# Altium schematic sheet Rikky-board_v0r0_NM.SchDoc
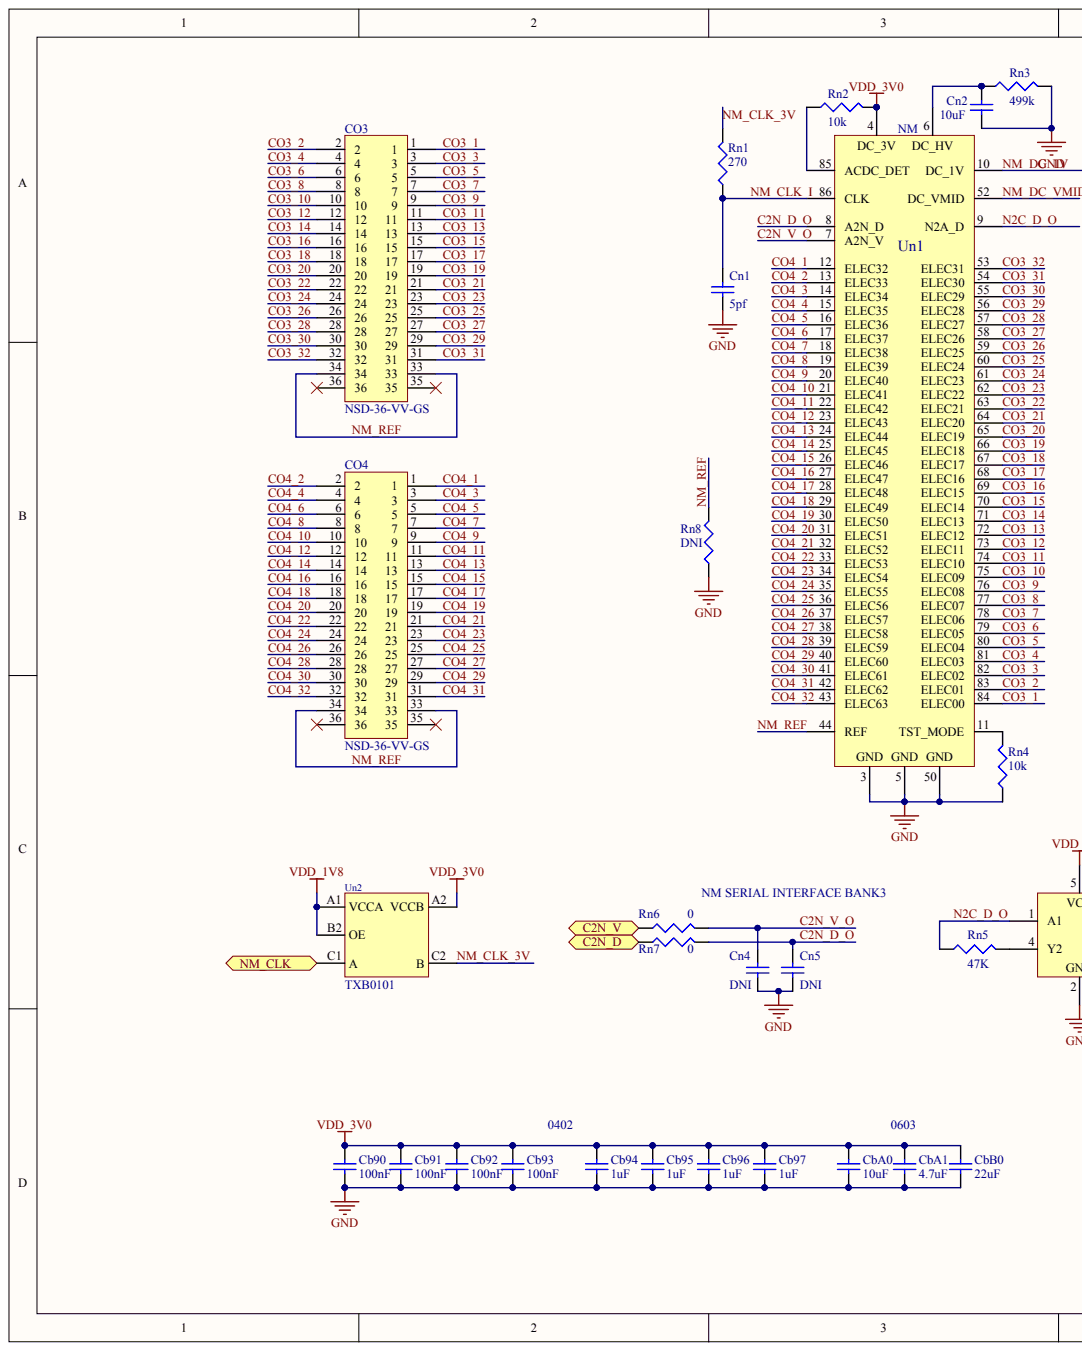
[diagram p0: Rikky-board_v0r0_NM.SchDoc, left]
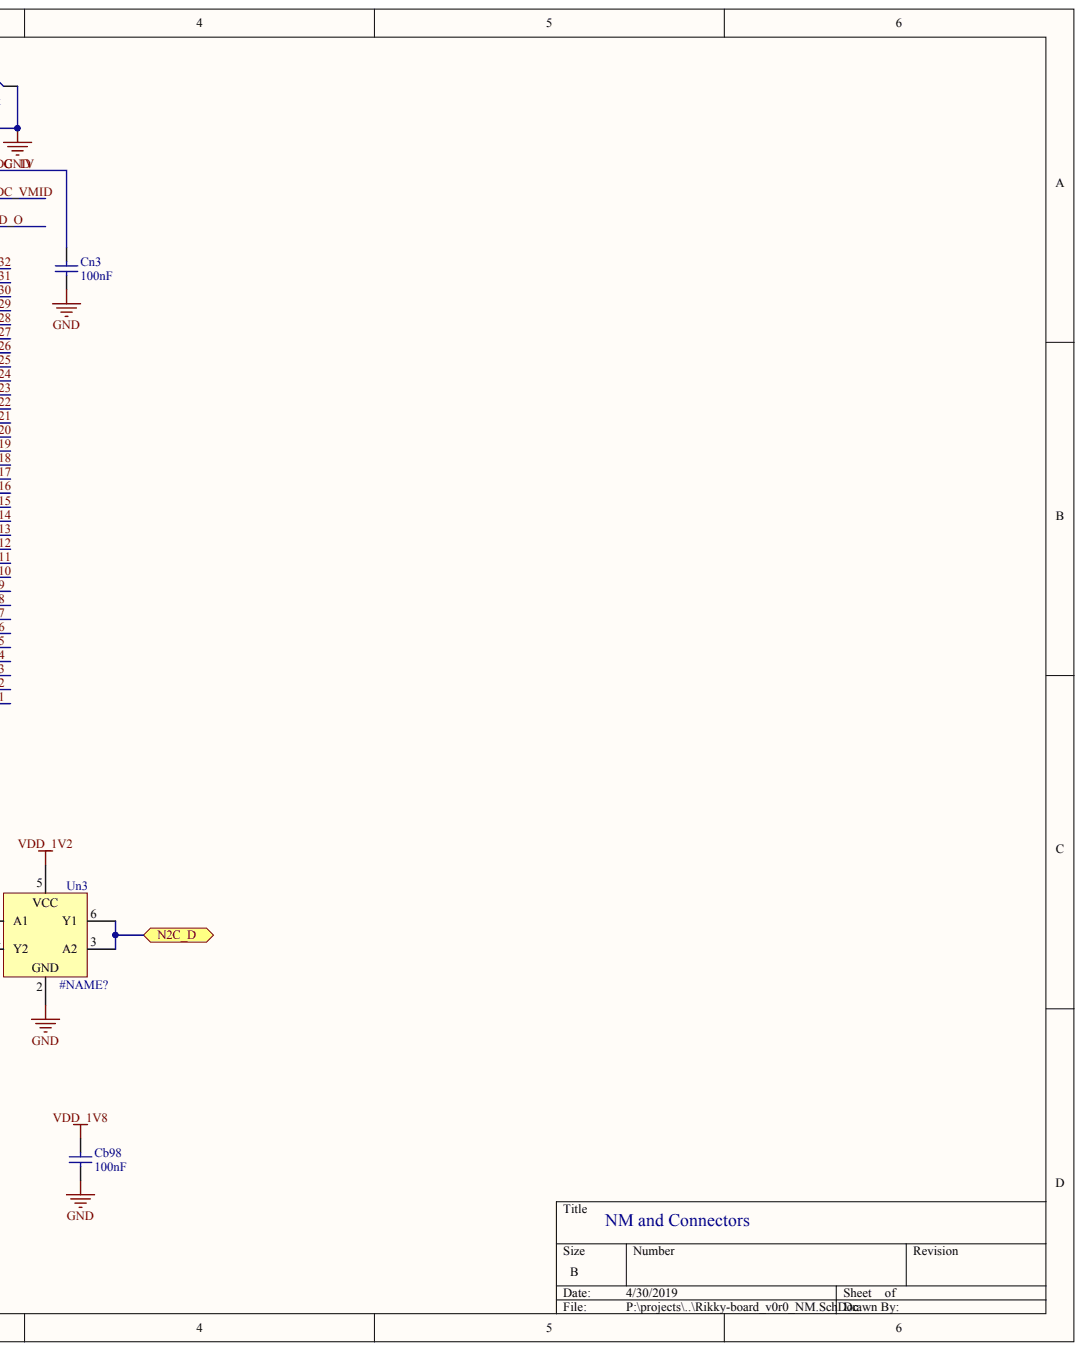
[diagram p1: Rikky-board_v0r0_NM.SchDoc, right]

## Parts
Cb90  value 100nF  (hidden comment: GCM155R71C104KA55D)
Cb91  value 100nF  (hidden comment: GCM155R71C104KA55D)
Cb92  value 100nF  (hidden comment: GCM155R71C104KA55D)
Cb93  value 100nF  (hidden comment: GCM155R71C104KA55D)
Cb94  value 1uF  (hidden comment: GRM155R61E105KA12D)
Cb95  value 1uF  (hidden comment: GRM155R61E105KA12D)
Cb96  value 1uF  (hidden comment: GRM155R61E105KA12D)
Cb97  value 1uF  (hidden comment: GRM155R61E105KA12D)
Cb98  value 100nF  (hidden comment: GCM155R71C104KA55D)
CbA0  value 10uF  (hidden comment: ZRB18AR61E106ME01L)
CbA1  value 4.7uF  (hidden comment: GRM188C81E475KE11D)
CbB0  value 22uF  (hidden comment: GRM188R61A226ME15D)
Cn1  value 5pf  (hidden comment: GRM1555C1H5R0BA01D)
Cn2  value 10uF  (hidden comment: ZRB18AR61E106ME01L)
Cn3  value 100nF  (hidden comment: GCM155R71C104KA55D)
Cn4  DNI
Cn5  DNI
CO3  NSD-36-VV-GS
CO4  NSD-36-VV-GS
Rn1  value 270  (hidden comment: ERJ-2RKF2700X)
Rn2  value 10k  (hidden comment: ERJ-2RKF1002X)
Rn3  value 499k  (hidden comment: ERJ-2RKF4993X)
Rn4  value 10k  (hidden comment: ERJ-2RKF1002X)
Rn5  value 47K  (hidden comment: ERJ-2RKF4702X)
Rn6  value 0  (hidden comment: ERJ-2GE0R00X)
Rn7  value 0  (hidden comment: ERJ-2GE0R00X)
Rn8  DNI
Un1  NM
Un2  TXB0101
Un3  NC7WV04L6X  (value not drawn)

## Ports
C2N_D, C2N_V, N2C_D, NM_CLK

## Nets
GND: Cb90.1 Cb91.1 Cb92.1 Cb93.1 Cb94.1 Cb95.1 Cb96.1 Cb97.1 Cb98.1 CbA0.1 CbA1.1 CbB0.1 Cn1.1 Cn2.1 Cn3.1 Cn4.1 Cn5.1 Rn3.2 Rn4.1 Rn8.1 Un1.3 Un1.5 Un1.50 Un2.B1 Un3.2
VDD_1V2: Un3.5
VDD_1V8: Cb98.2 Un2.A1(VCCA) Un2.B2(OE)
VDD_3V0: Cb90.2 Cb91.2 Cb92.2 Cb93.2 Cb94.2 Cb95.2 Cb96.2 Cb97.2 CbA0.2 CbA1.2 CbB0.2 Rn2.2 Un1.4 Un2.A2(VCCB)
C2N_D: Rn7.1 ; port C2N_D
C2N_D_O: Cn5.2 Rn7.2 Un1.8(A2N_D)
C2N_V: Rn6.1 ; port C2N_V
C2N_V_O: Cn4.2 Rn6.2 Un1.7(A2N_V)
CO3_1: CO3.1 Un1.84(ELEC00)
CO3_2: CO3.2 Un1.83(ELEC01)
CO3_3: CO3.3 Un1.82(ELEC02)
CO3_4: CO3.4 Un1.81(ELEC03)
CO3_5: CO3.5 Un1.80(ELEC04)
CO3_6: CO3.6 Un1.79(ELEC05)
CO3_7: CO3.7 Un1.78(ELEC06)
CO3_8: CO3.8 Un1.77(ELEC07)
CO3_9: CO3.9 Un1.76(ELEC08)
CO3_10: CO3.10 Un1.75(ELEC09)
CO3_11: CO3.11 Un1.74(ELEC10)
CO3_12: CO3.12 Un1.73(ELEC11)
CO3_13: CO3.13 Un1.72(ELEC12)
CO3_14: CO3.14 Un1.71(ELEC13)
CO3_15: CO3.15 Un1.70(ELEC14)
CO3_16: CO3.16 Un1.69(ELEC15)
CO3_17: CO3.17 Un1.68(ELEC16)
CO3_18: CO3.18 Un1.67(ELEC17)
CO3_19: CO3.19 Un1.66(ELEC18)
CO3_20: CO3.20 Un1.65(ELEC19)
CO3_21: CO3.21 Un1.64(ELEC20)
CO3_22: CO3.22 Un1.63(ELEC21)
CO3_23: CO3.23 Un1.62(ELEC22)
CO3_24: CO3.24 Un1.61(ELEC23)
CO3_25: CO3.25 Un1.60(ELEC24)
CO3_26: CO3.26 Un1.59(ELEC25)
CO3_27: CO3.27 Un1.58(ELEC26)
CO3_28: CO3.28 Un1.57(ELEC27)
CO3_29: CO3.29 Un1.56(ELEC28)
CO3_30: CO3.30 Un1.55(ELEC29)
CO3_31: CO3.31 Un1.54(ELEC30)
CO3_32: CO3.32 Un1.53(ELEC31)
CO4_1: CO4.1 Un1.12(ELEC32)
CO4_2: CO4.2 Un1.13(ELEC33)
CO4_3: CO4.3 Un1.14(ELEC34)
CO4_4: CO4.4 Un1.15(ELEC35)
CO4_5: CO4.5 Un1.16(ELEC36)
CO4_6: CO4.6 Un1.17(ELEC37)
CO4_7: CO4.7 Un1.18(ELEC38)
CO4_8: CO4.8 Un1.19(ELEC39)
CO4_9: CO4.9 Un1.20(ELEC40)
CO4_10: CO4.10 Un1.21(ELEC41)
CO4_11: CO4.11 Un1.22(ELEC42)
CO4_12: CO4.12 Un1.23(ELEC43)
CO4_13: CO4.13 Un1.24(ELEC44)
CO4_14: CO4.14 Un1.25(ELEC45)
CO4_15: CO4.15 Un1.26(ELEC46)
CO4_16: CO4.16 Un1.27(ELEC47)
CO4_17: CO4.17 Un1.28(ELEC48)
CO4_18: CO4.18 Un1.29(ELEC49)
CO4_19: CO4.19 Un1.30(ELEC50)
CO4_20: CO4.20 Un1.31(ELEC51)
CO4_21: CO4.21 Un1.32(ELEC52)
CO4_22: CO4.22 Un1.33(ELEC53)
CO4_23: CO4.23 Un1.34(ELEC54)
CO4_24: CO4.24 Un1.35(ELEC55)
CO4_25: CO4.25 Un1.36(ELEC56)
CO4_26: CO4.26 Un1.37(ELEC57)
CO4_27: CO4.27 Un1.38(ELEC58)
CO4_28: CO4.28 Un1.39(ELEC59)
CO4_29: CO4.29 Un1.40(ELEC60)
CO4_30: CO4.30 Un1.41(ELEC61)
CO4_31: CO4.31 Un1.42(ELEC62)
CO4_32: CO4.32 Un1.43(ELEC63)
N2C_D: Un3.3(A2) Un3.6(Y1) ; port N2C_D
N2C_D_O: Rn5.1 Un1.9(N2A_D) Un3.1(A1)
NM_CLK: Un2.C1(A) ; port NM_CLK
NM_CLK_3V: Rn1.2 Un2.C2(B)
NM_CLK_I: Cn1.2 Rn1.1 Un1.86(CLK)
NM_DC_1V: Cn3.2 Un1.10(DC_1V)
NM_DC_VMID: Un1.52(DC_VMID)
NM_REF: CO3.33 CO3.34 CO4.33 CO4.34 Rn8.2 Un1.44(REF)
NetCn2_2: Cn2.2 Rn3.1 Un1.6
NetRn2_1: Rn2.1 Un1.85(ACDC_DET)
NetRn4_2: Rn4.2 Un1.11(TST_MODE)
NetRn5_2: Rn5.2 Un3.4(Y2)

Not connected: CO3 35 36; CO4 35 36

## Library data of the parts
Cb90-Cb98 CbA0 CbA1 CbB0 Cn1-Cn3 = (no symbol name): Manufacturer: Murata; Supplier: Digikey
  Cb90-Cb93 Cb98 Cn3: Manufacturer PN: GCM155R71C104KA55D; Supplier PN: 490-4759-1-ND
  Cb94-Cb97: Manufacturer PN: GRM155R61E105KA12D; Supplier PN: 490-10017-1-ND
  CbA0: Supplier PN: 490-10991-1-ND; Manufacturer PN: ZRB18AR61E106ME01L
  CbA1: Supplier PN: 490-7199-1-ND; Manufacturer PN: GRM188C81E475KE11D
  CbB0: Supplier PN: 490-10476-1-ND; Manufacturer PN: GRM188R61A226ME15D
  Cn1: Manufacturer PN: GRM1555C1H5R0BA01D; Supplier PN: 490-8213-1-ND
  Cn2: Manufacturer PN: ZRB18AR61E106ME01L; Supplier PN: 490-10991-1-ND
CO3 CO4 = (no symbol name): Manufacturer PN: A79027-001; Manufacturer: Omnetics; Note: NSD-36-VV-GS with four guide pins; Supplier PN: A79027-001; Supplier: Omnetics
Rn1-Rn8 = (no symbol name): Manufacturer: Panasonic; Power: 1/10W; Supplier: Digikey; Package: 0402
  Rn1: Manufacturer PN: ERJ-2RKF2700X; Supplier P/N: P270LCT-ND
  Rn2 Rn4: Manufacturer PN: ERJ-2RKF1002X; Supplier P/N: P10.0KLCT-ND
  Rn3: Manufacturer PN: ERJ-2RKF4993X; Supplier P/N: P499KLCT-ND
  Rn5: Manufacturer PN: ERJ-2RKF4702X; Supplier P/N: P47.0KLCT-ND
  Rn6 Rn7: Manufacturer PN: ERJ-2GE0R00X; Supplier P/N: P0.0JCT-ND
  Rn8: Manufacturer PN: DNI; Value: DNI
Un1 = (no symbol name): Manufacturer: Cortera
Un2 = (no symbol name): Manufacturer PN: TXB0101YZPR; Manufacturer: TI; Supplier PN: 296-21927-1-ND; Supplier: Digikey
Un3 = (no symbol name): Manufacturer: Fairchild; Manufacturer PN: NC7WV04L6X; Supplier: Digikey; Supplier PN: NC7WV04L6XCT-ND
Cn4 Cn5 = (no symbol name): Supplier: Digikey; Manufacturer PN: DNI; Value: DNI
